# Altium schematic sheet tsop.SchDoc
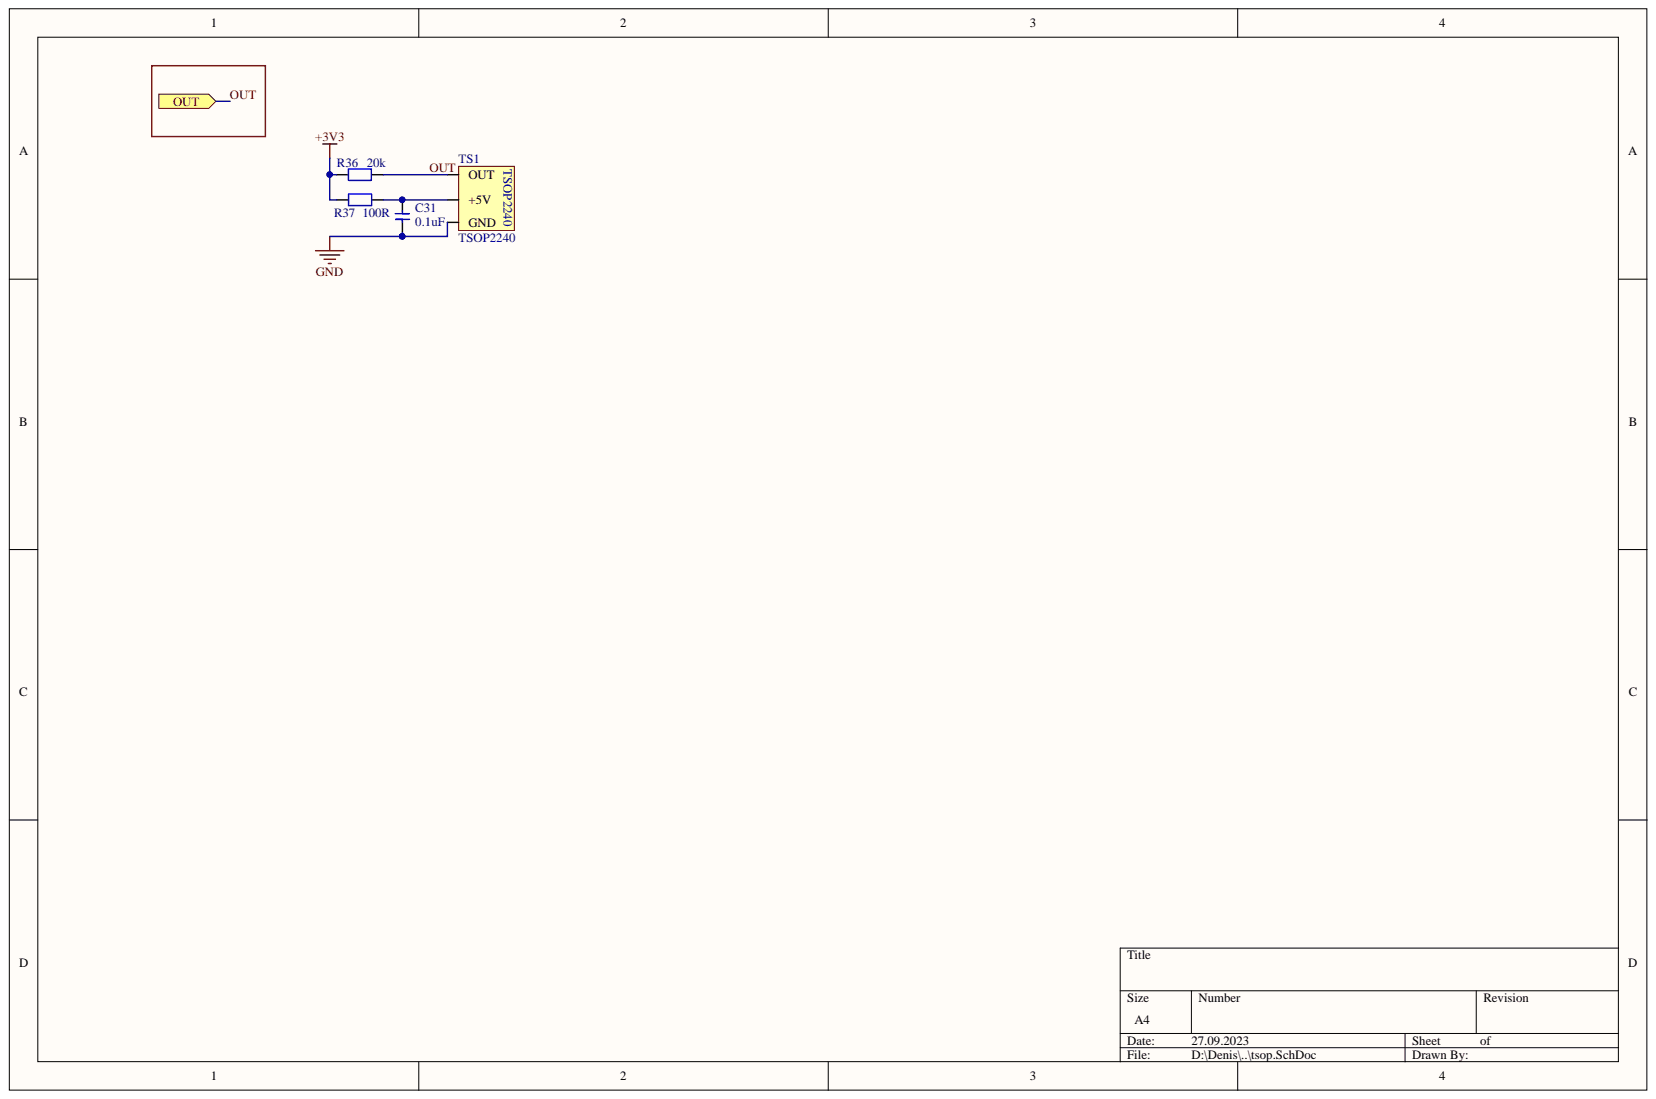
[diagram p0: tsop.SchDoc]

## Parts
C31  0.1uF  [cap0603]
R36  20k  [res0603]
R37  100R  [res0603]
TS1  TSOP2240  [TSOP2240]

## Ports
OUT

## Nets
+3V3: R36.2 R37.1
GND: C31.2 TS1.3
OUT: R36.1 TS1.1 ; port OUT
NetC31_1: C31.1 R37.2 TS1.2

## Library data of the parts
C31 = Cap SMD: Component Kind: Standard; Library Name: GKirik.SchLib
R36 R37 = Resistor SMD: Component Kind: Standard; Library Name: GKirik.SchLib
TS1 = TSOP2240: Component Kind: Standard; Library Name: GKirik.SchLib
